# Altium schematic sheet USB_FS.SchDoc
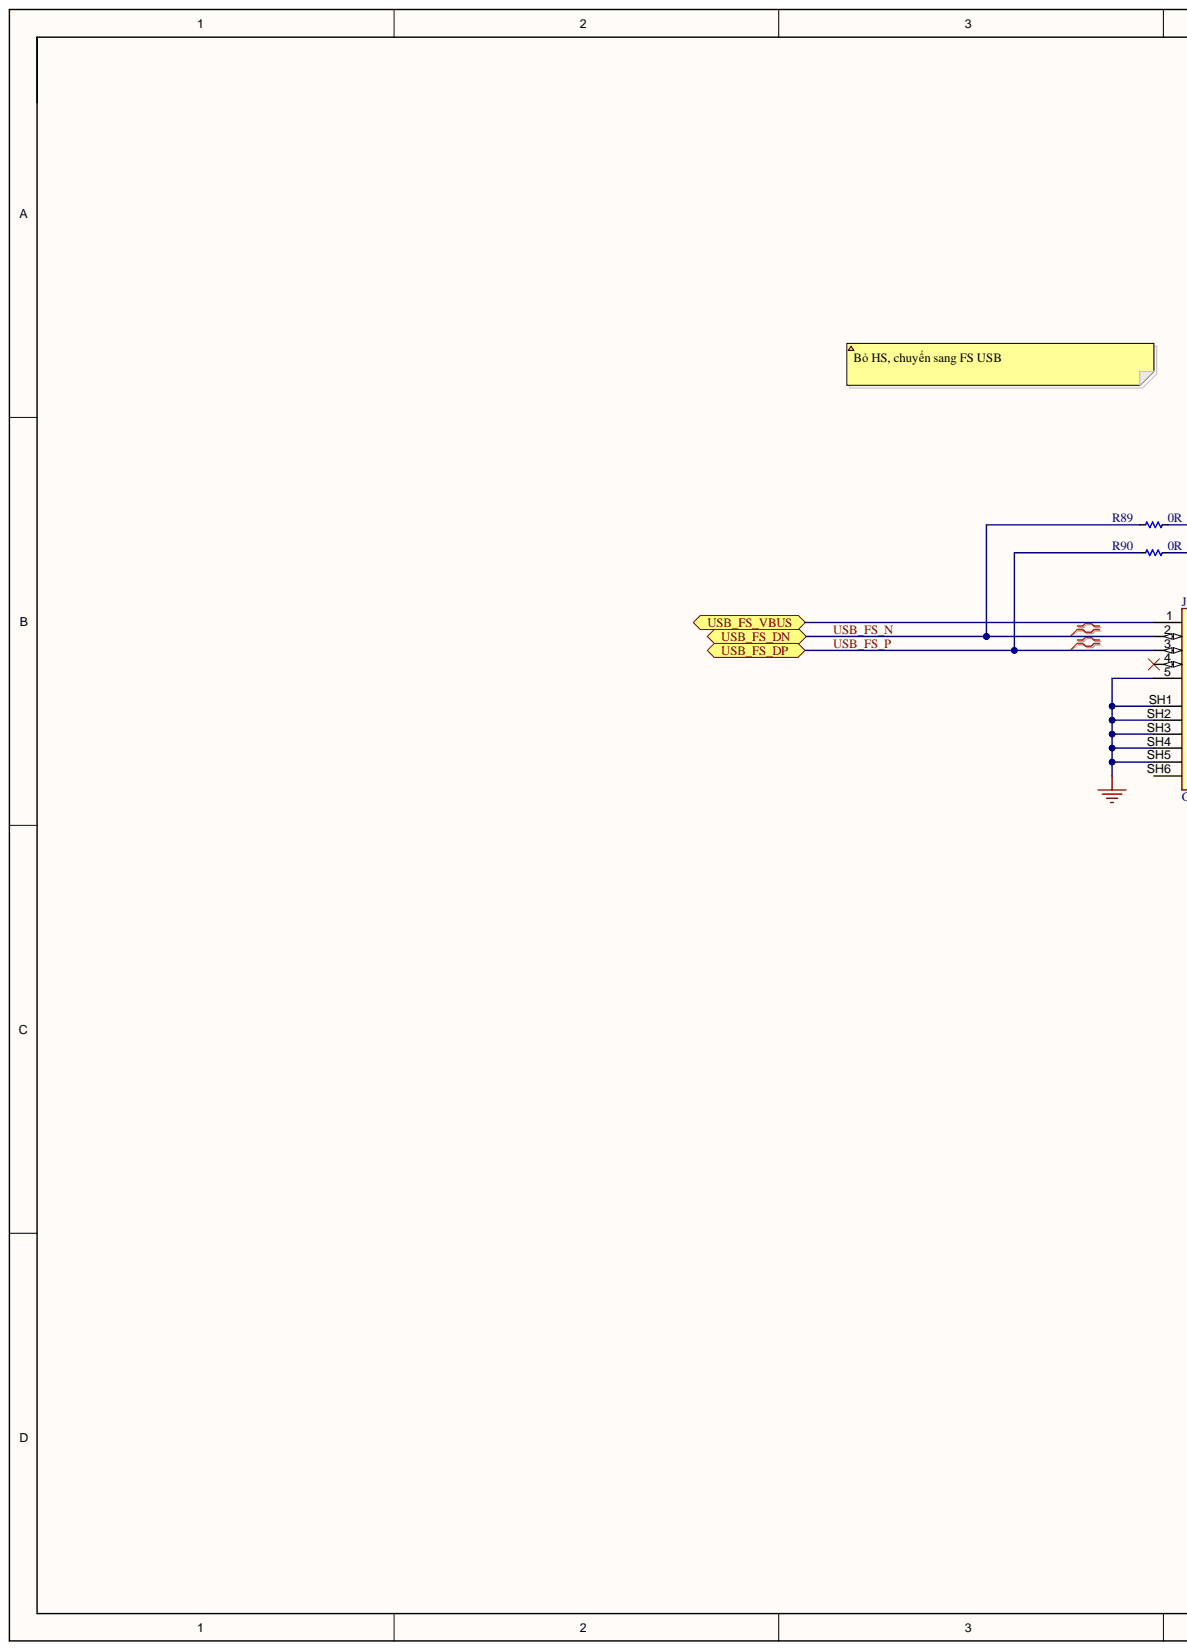
[diagram p0: USB_FS.SchDoc, left]
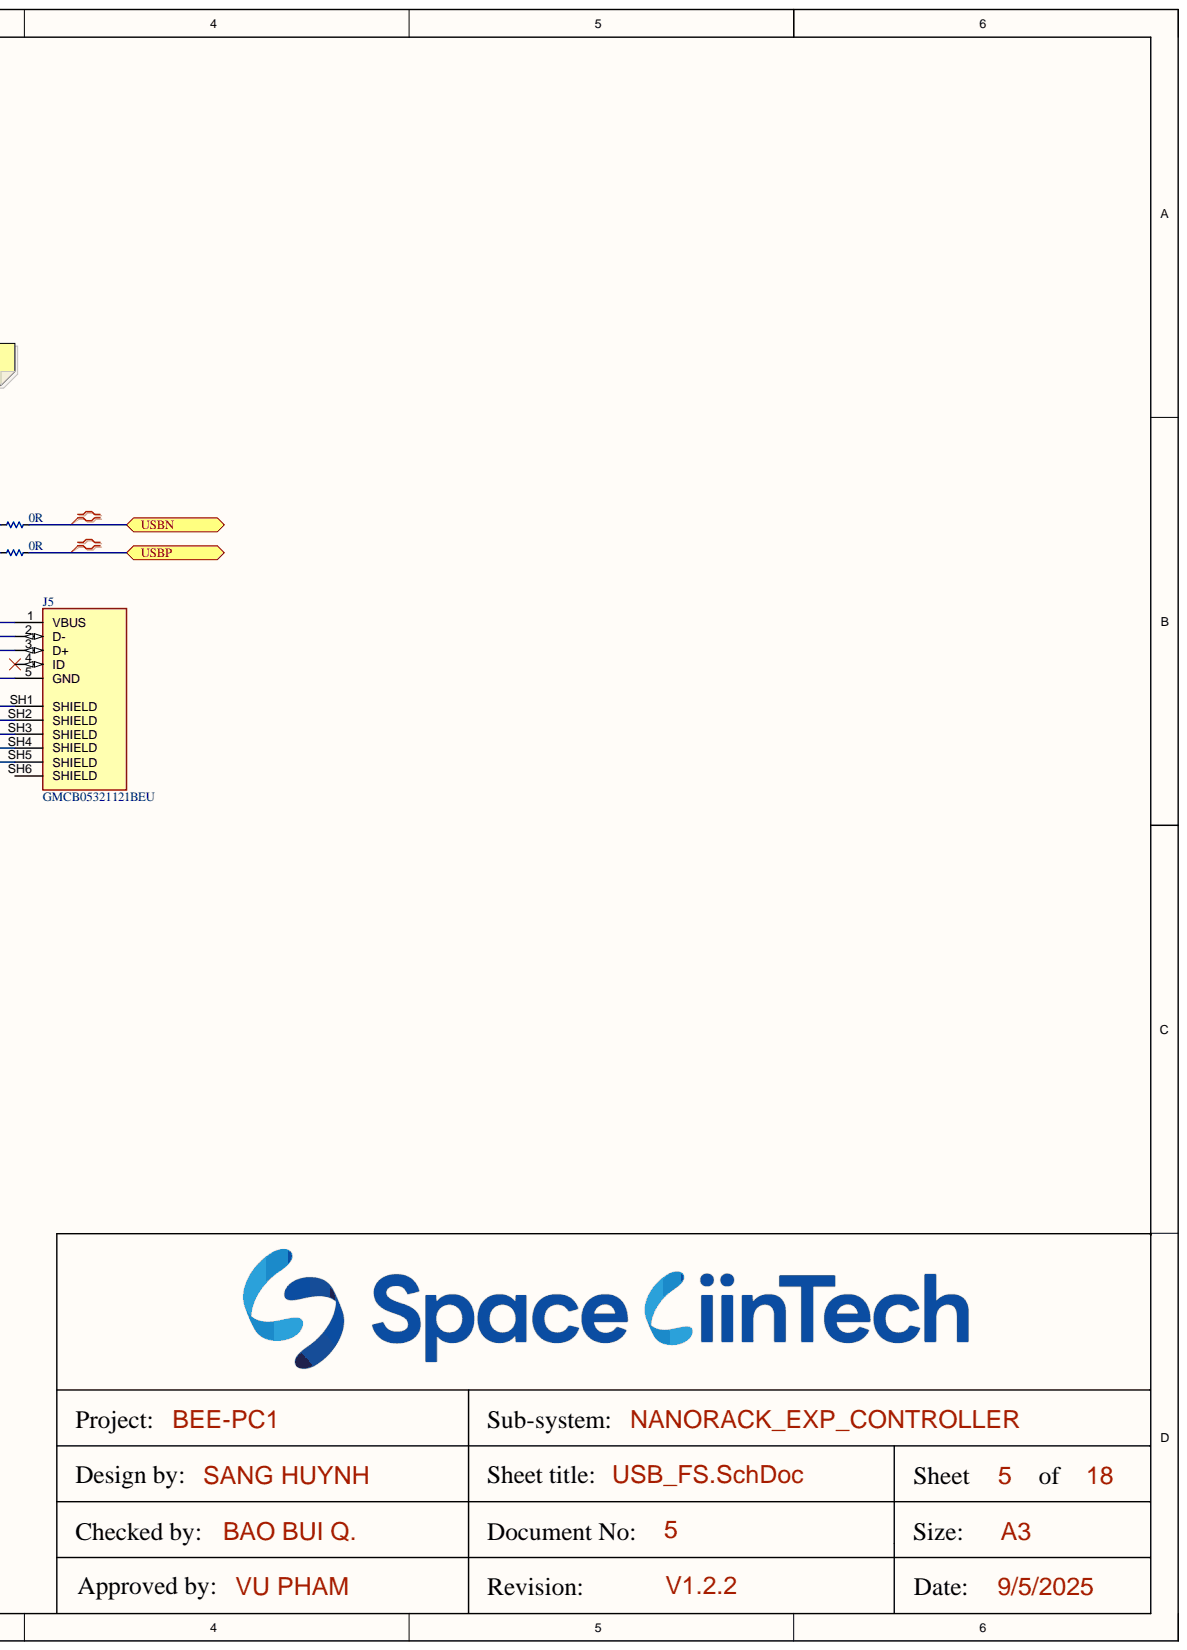
[diagram p1: USB_FS.SchDoc, right]

## Parts
J5  GMCB05321121BEU  [AMPHENOL_GMCB05321121BEU]
R89  0R  [RES_C_1608X60]
R90  0R  [RES_C_1608X60]

## Ports
USB_FS_DN, USB_FS_DP, USB_FS_VBUS, USBN, USBP

## Nets
GND: J5.5(GND) J5.SH1(SHIELD) J5.SH2(SHIELD) J5.SH3(SHIELD) J5.SH4(SHIELD) J5.SH5(SHIELD)
USB_FS_N: J5.2(D-) R89.1 ; port USB_FS_DN
USB_FS_P: J5.3(D+) R90.1 ; port USB_FS_DP
USB_FS_VBUS: J5.1(VBUS) ; port USB_FS_VBUS
USBN: R89.2 ; port USBN
USBP: R90.2 ; port USBP

Not connected: J5 4(ID) SH6(SHIELD)

## Library data of the parts
J5 = GMCB05321121BEU: Micro USB 2.0 Type B Receptacle Right Angle SMT, Tape and Reel Packaging; MF: Amphenol Commercial Products; Check_prices: https://www.snapeda.com/parts/GMCB05321121BEU/Amphenol/view-part/?ref=eda; Component Kind: Standard; Library Name: COMM_SCHLIB.SchLib; MP: GMCB05321121BEU; Package: None; SnapEDA_Link: https://www.snapeda.com/parts/GMCB05321121BEU/Amphenol/view-part/?ref=snap
R89 R90 = _RES_B_2: RES; Component Kind: Standard; Library Name: LABLIB.SchLib
